# Altium schematic sheet MCU_unit.SchDoc
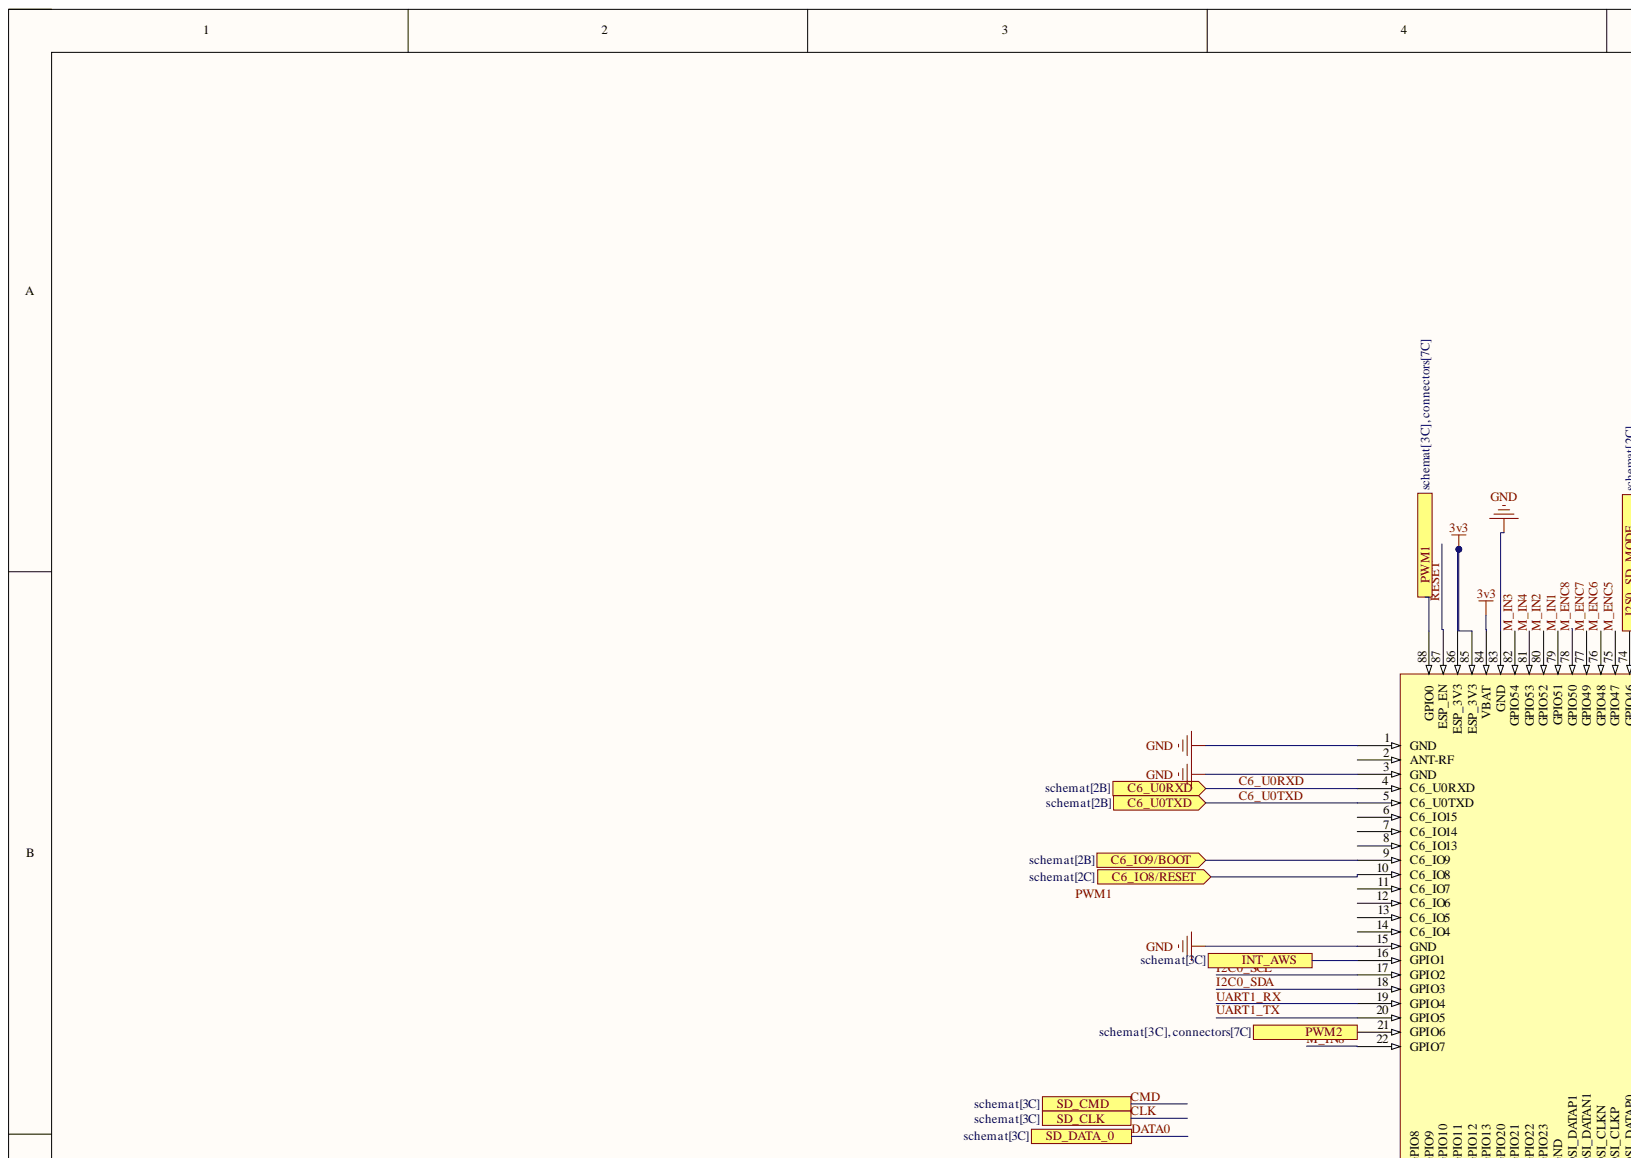
[diagram p0: MCU_unit.SchDoc, top left]
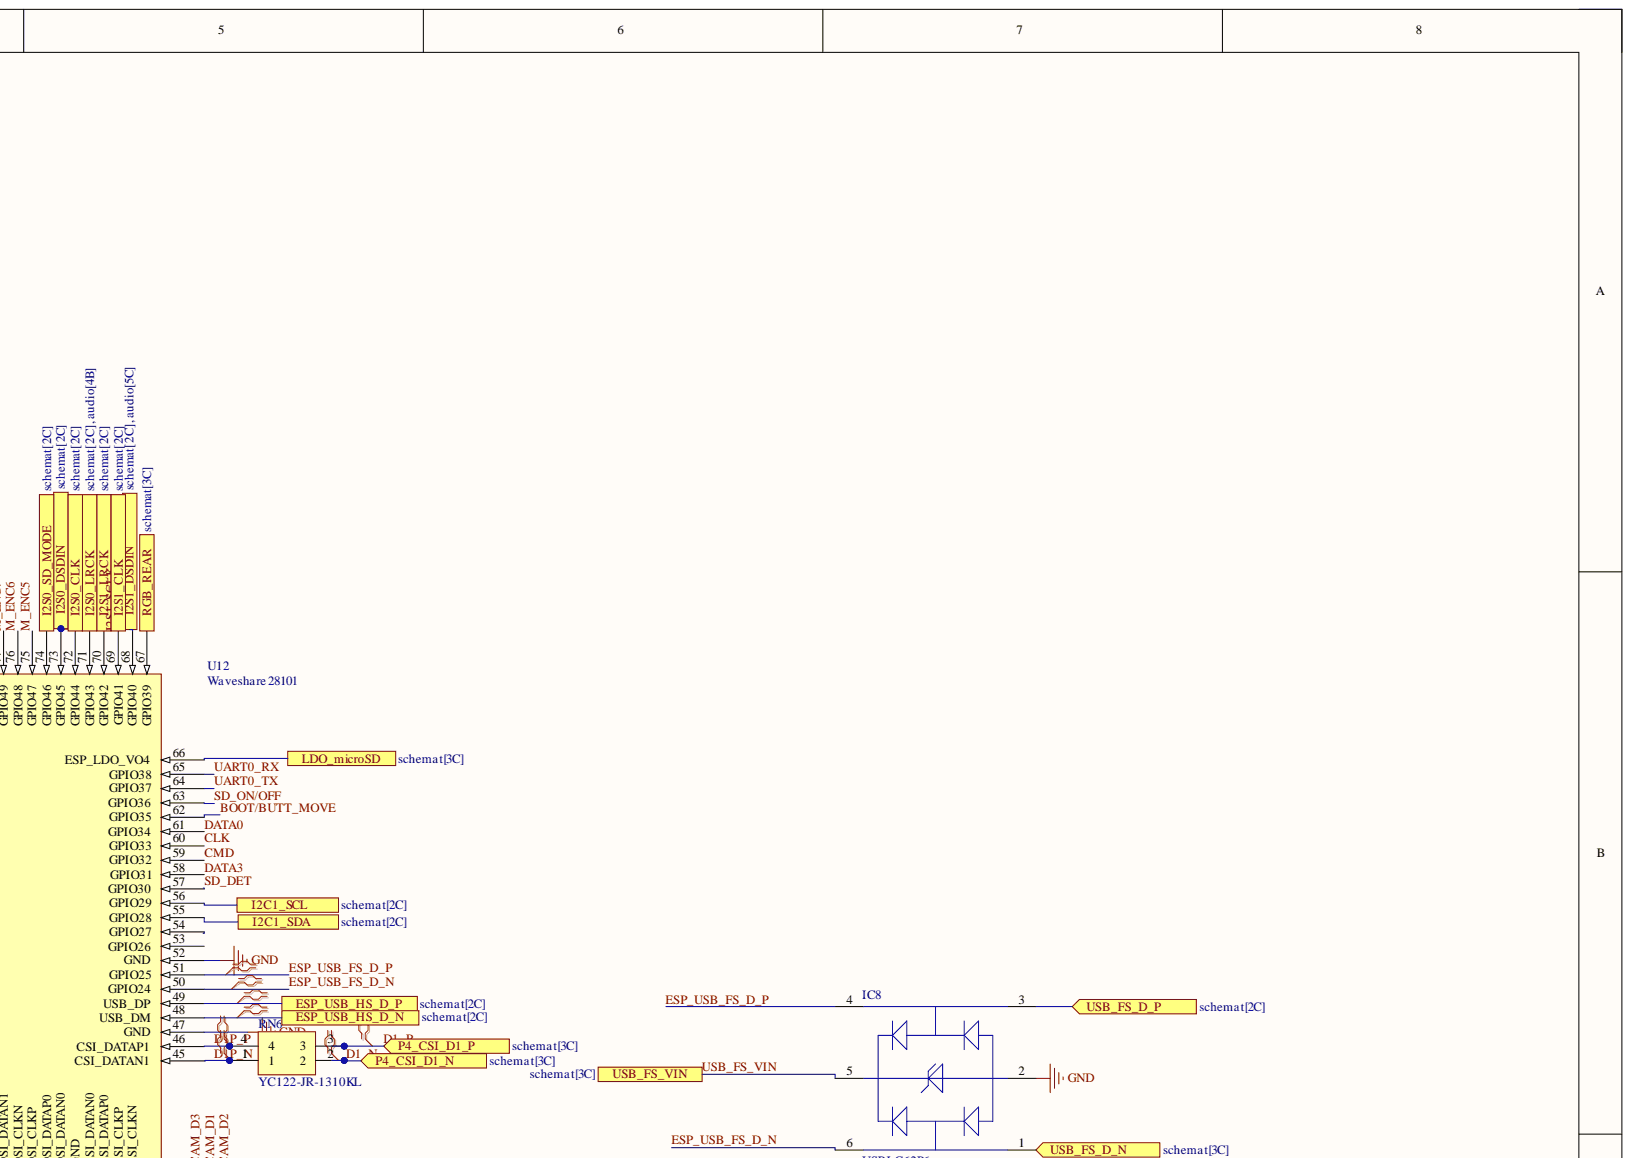
[diagram p1: MCU_unit.SchDoc, top right]
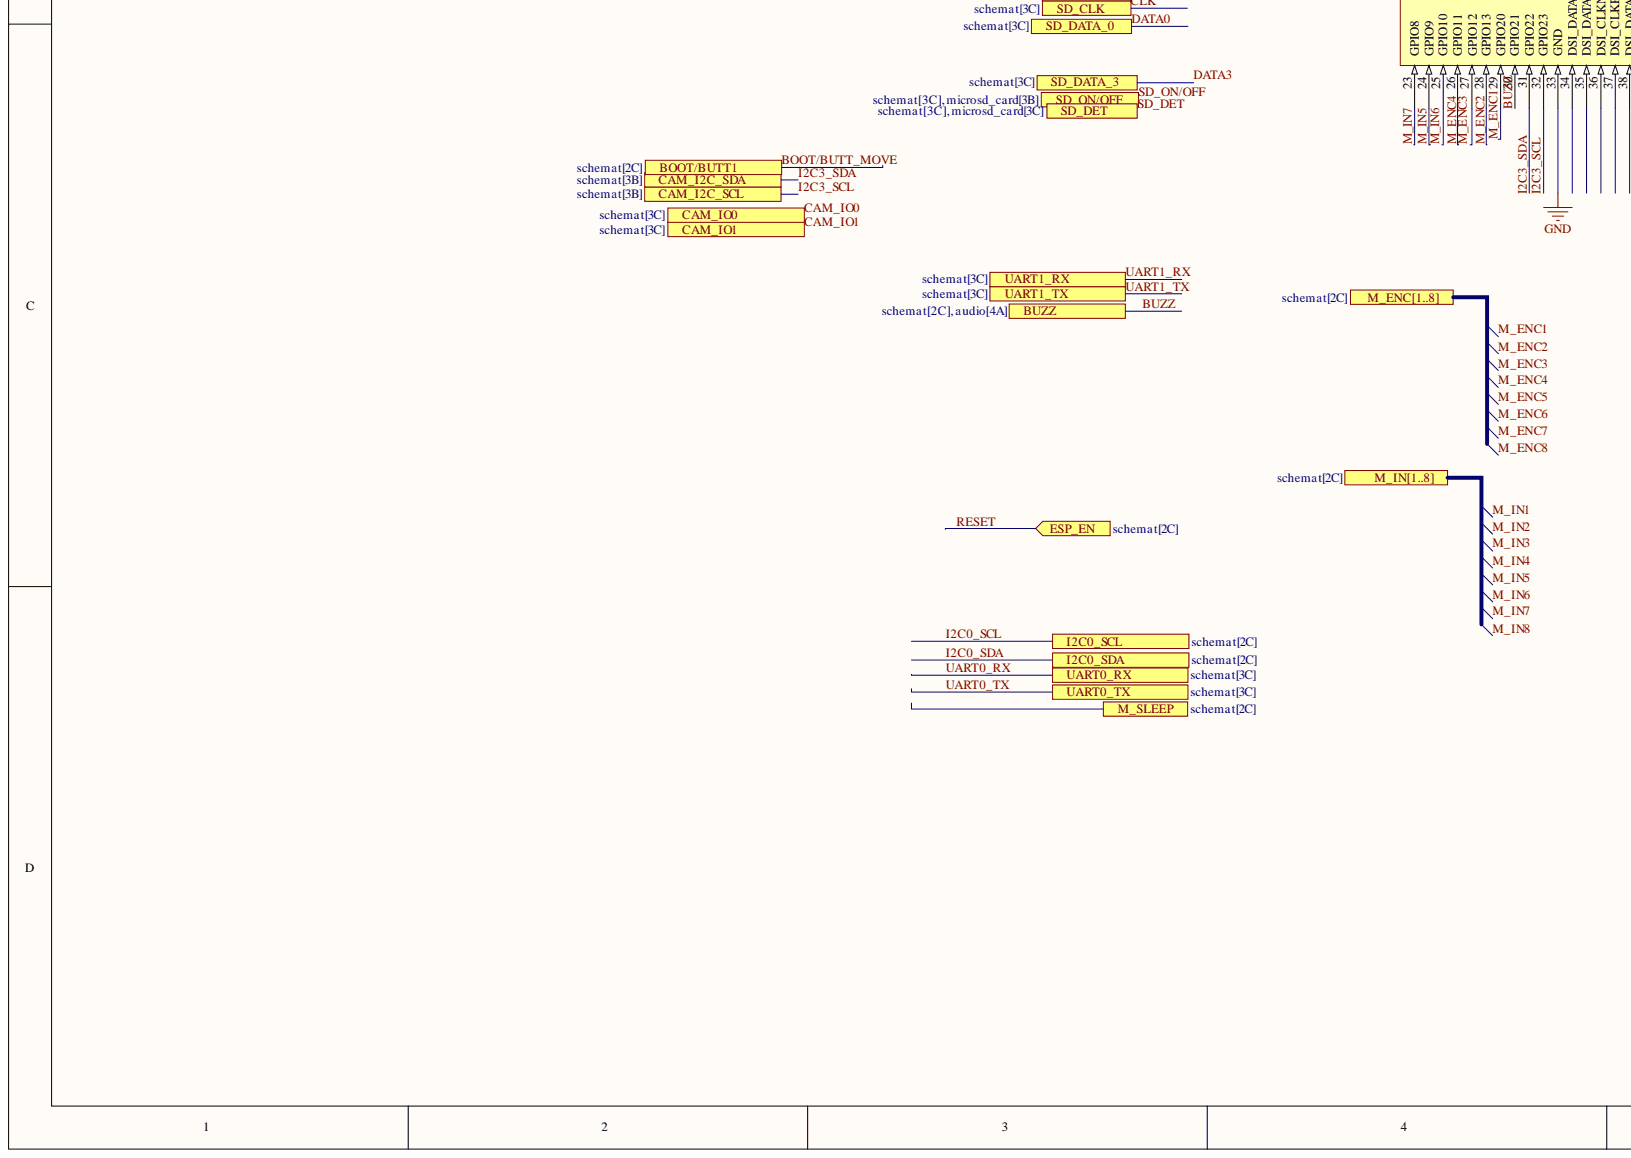
[diagram p2: MCU_unit.SchDoc, bottom left]
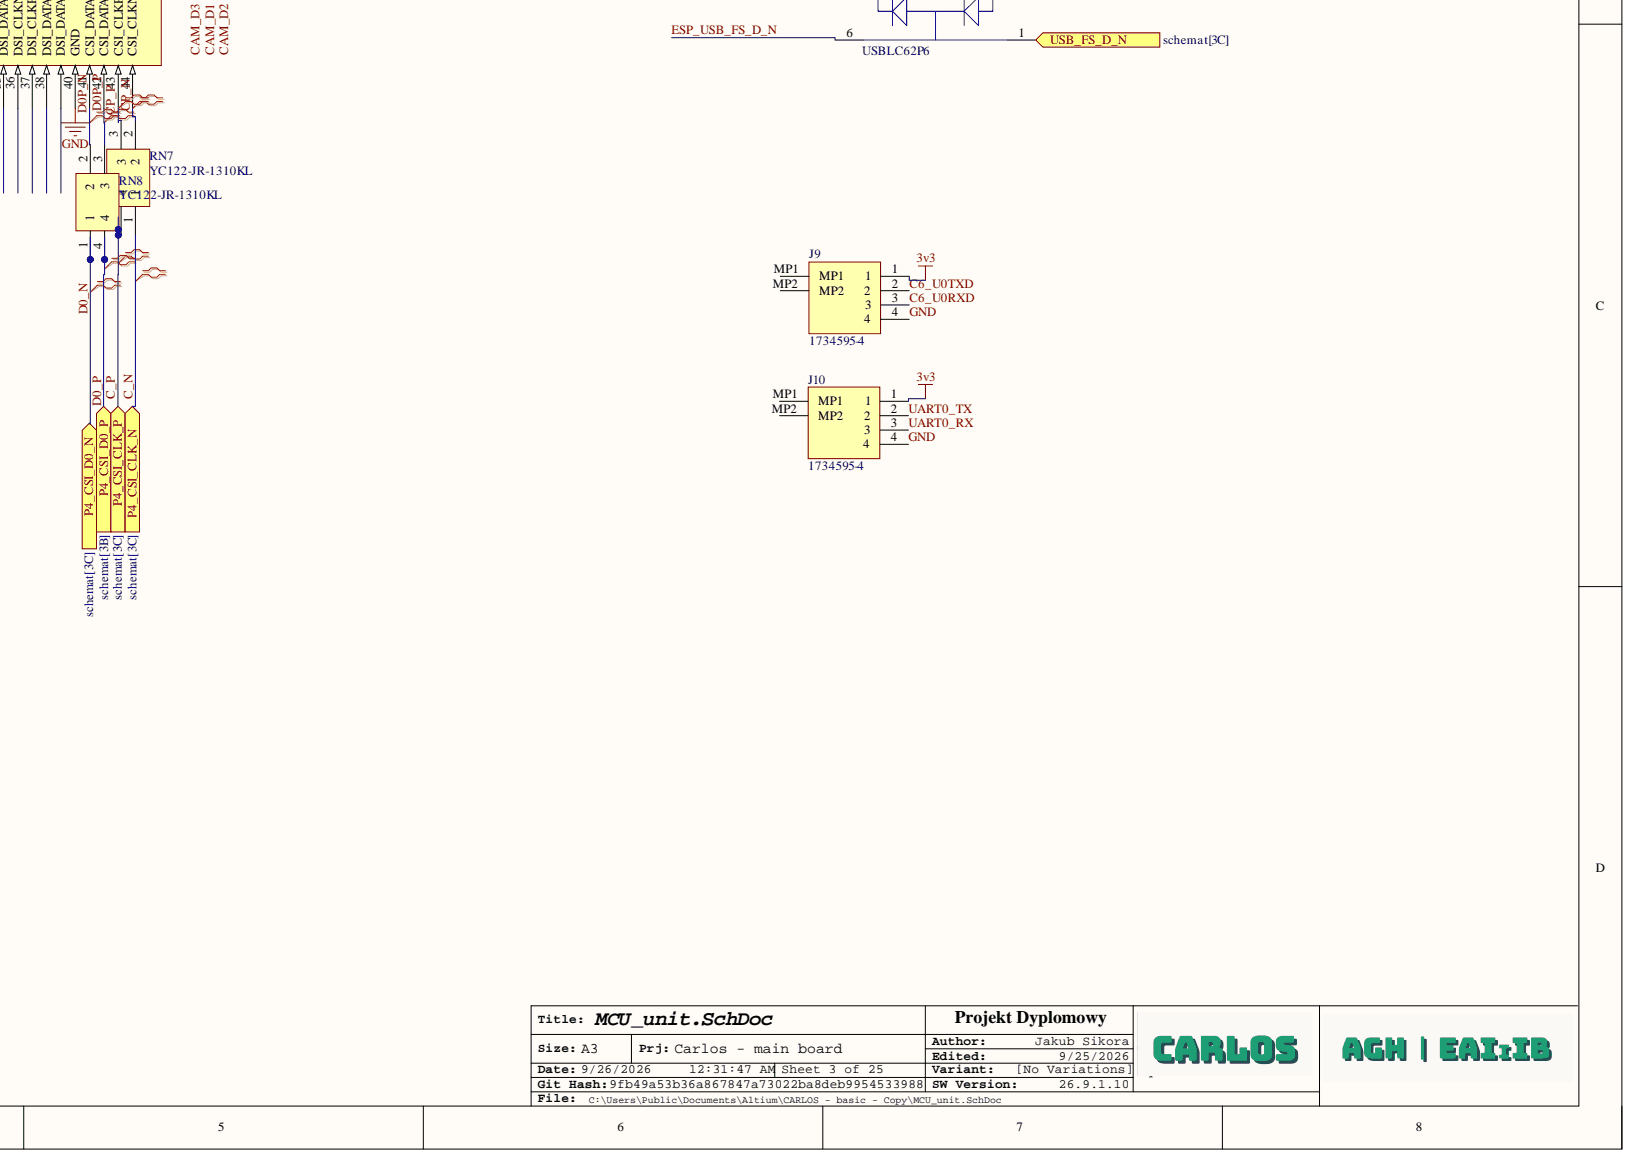
[diagram p3: MCU_unit.SchDoc, bottom right]

## Parts
IC8  USBLC62P6  [USBLC62P6]
J9  1734595-4  [17345954]
J10  1734595-4  [17345954]
RN6  YC122-JR-1310KL  [YC122JR0722KL]
RN7  YC122-JR-1310KL  [YC122JR0722KL]
RN8  YC122-JR-1310KL  [YC122JR0722KL]
U12  Waveshare 28101  [waveshare esp32p4]

## Ports
BOOT/BUTT1, BUZZ, C6_IO8/RESET, C6_IO9/BOOT, C6_U0RXD, C6_U0TXD, CAM_I2C_SCL, CAM_I2C_SDA, CAM_IO0, CAM_IO1, ESP_EN, ESP_USB_HS_D_N, ESP_USB_HS_D_P, I2C0_SCL, I2C0_SDA, I2C1_SCL, I2C1_SDA, I2S0_CLK, I2S0_DSDIN, I2S0_LRCK, I2S0_SD_MODE, I2S1_CLK, I2S1_DSDIN, I2S1_LRCK, INT_AWS, LDO_microSD, M_ENC1, M_ENC2, M_ENC3, M_ENC4, M_ENC5, M_ENC6, M_ENC7, M_ENC8, M_ENC[1..8], M_IN1, M_IN2, M_IN3, M_IN4, M_IN5, M_IN6, M_IN7, M_IN8, M_IN[1..8], M_SLEEP, P4_CSI_CLK_N, P4_CSI_CLK_P, P4_CSI_D0_N, P4_CSI_D0_P, P4_CSI_D1_N, P4_CSI_D1_P, PWM1, PWM2, RGB_REAR, SD_CLK, SD_CMD, SD_DATA_0, SD_DATA_3, SD_DET, SD_ON/OFF, UART0_RX, UART0_TX, UART1_RX, UART1_TX, USB_FS_D_N, USB_FS_D_P, USB_FS_VIN

## Nets
3v3: J9.1 J10.1 U12.84(VBAT) U12.85(ESP_3V3) U12.86(ESP_3V3)
BOOT/BUTT_MOVE: U12.62(GPIO35) ; port BOOT/BUTT1
BUZZ: U12.30(GPIO21) ; port BUZZ
C6_IO8/RESET: U12.10(C6_IO8) ; port C6_IO8/RESET
C6_IO9/BOOT: U12.9(C6_IO9) ; port C6_IO9/BOOT
C6_U0RXD: J9.3 U12.4(C6_U0RXD) ; port C6_U0RXD
C6_U0TXD: J9.2 U12.5(C6_U0TXD) ; port C6_U0TXD
C_N: RN7.1 ; port P4_CSI_CLK_N
C_P: RN7.4 ; port P4_CSI_CLK_P
CLK: U12.60(GPIO33) ; port SD_CLK
CMD: U12.59(GPIO32) ; port SD_CMD
CP_N: RN7.2 U12.44(CSI_CLKN)
CP_P: RN7.3 U12.43(CSI_CLKP)
D0_N: RN8.1 ; port P4_CSI_D0_N
D0_P: RN8.4 ; port P4_CSI_D0_P
D0P_N: RN8.2 U12.41(CSI_DATAN0)
D0P_P: RN8.3 U12.42(CSI_DATAP0)
D1_N: RN6.2 ; port P4_CSI_D1_N
D1_P: RN6.3 ; port P4_CSI_D1_P
D1P_N: RN6.1 U12.45(CSI_DATAN1)
D1P_P: RN6.4 U12.46(CSI_DATAP1)
DATA0: U12.61(GPIO34) ; port SD_DATA_0
DATA3: U12.58(GPIO31) ; port SD_DATA_3
ESP_USB_FS_D_N: IC8.6 U12.50(GPIO24)
ESP_USB_FS_D_P: IC8.4 U12.51(GPIO25)
ESP_USB_HS_D_N: U12.48(USB_DM) ; port ESP_USB_HS_D_N
ESP_USB_HS_D_P: U12.49(USB_DP) ; port ESP_USB_HS_D_P
GND: IC8.2 J9.4 J10.4 U12.1(GND) U12.3(GND) U12.15(GND) U12.33(GND) U12.40(GND) U12.47(GND) U12.52(GND) U12.83(GND)
I2C0_SCL: U12.17(GPIO2) ; port I2C0_SCL
I2C0_SDA: U12.18(GPIO3) ; port I2C0_SDA
I2C1_SCL: U12.56(GPIO29) ; port I2C1_SCL
I2C1_SDA: U12.55(GPIO28) ; port I2C1_SDA
I2C3_SCL: U12.32(GPIO23) ; port CAM_I2C_SCL
I2C3_SDA: U12.31(GPIO22) ; port CAM_I2C_SDA
I2S0_DSDIN: U12.73(GPIO45) ; port I2S0_DSDIN
I2S0_LRCK: U12.71(GPIO43) ; port I2S0_LRCK
I2S0_SCLK: U12.72(GPIO44) ; port I2S0_CLK
I2S0_SD_MODE: U12.74(GPIO46) ; port I2S0_SD_MODE
I2S1_DSDIN: U12.68(GPIO40) ; port I2S1_DSDIN
I2S1_LRCK: U12.70(GPIO42) ; port I2S1_LRCK
I2S1_SCLK: U12.69(GPIO41) ; port I2S1_CLK
INT_AWS: U12.16(GPIO1) ; port INT_AWS
LDO_microSD: U12.66(ESP_LDO_VO4) ; port LDO_microSD
M_ENC1: U12.29(GPIO20) ; port M_ENC[1..8]  [also labelled ]
M_ENC2: U12.28(GPIO13) ; port M_ENC[1..8]  [also labelled ]
M_ENC3: U12.27(GPIO12) ; port M_ENC[1..8]  [also labelled ]
M_ENC4: U12.26(GPIO11) ; port M_ENC[1..8]  [also labelled ]
M_ENC5: U12.75(GPIO47) ; port M_ENC[1..8]  [also labelled ]
M_ENC6: U12.76(GPIO48) ; port M_ENC[1..8]  [also labelled ]
M_ENC7: U12.77(GPIO49) ; port M_ENC[1..8]  [also labelled ]
M_ENC8: U12.78(GPIO50) ; port M_ENC[1..8]  [also labelled ]
M_IN1: U12.79(GPIO51) ; port M_IN[1..8]  [also labelled ]
M_IN2: U12.80(GPIO52) ; port M_IN[1..8]  [also labelled ]
M_IN3: U12.82(GPIO54) ; port M_IN[1..8]  [also labelled ]
M_IN4: U12.81(GPIO53) ; port M_IN[1..8]  [also labelled ]
M_IN5: U12.24(GPIO9) ; port M_IN[1..8]  [also labelled ]
M_IN6: U12.25(GPIO10) ; port M_IN[1..8]  [also labelled ]
M_IN7: U12.23(GPIO8) ; port M_IN[1..8]  [also labelled ]
M_IN8: U12.22(GPIO7) ; port M_IN[1..8]  [also labelled ]
PWM1: U12.88(GPIO0) ; port PWM1
PWM2: U12.21(GPIO6) ; port PWM2
RESET: U12.87(ESP_EN) ; port ESP_EN
RGB_REAR: U12.67(GPIO39) ; port RGB_REAR
SD_DET: U12.57(GPIO30) ; port SD_DET
SD_ON/OFF: U12.63(GPIO36) ; port SD_ON/OFF
UART0_RX: J10.3 U12.65(GPIO38) ; port UART0_RX
UART0_TX: J10.2 U12.64(GPIO37) ; port UART0_TX
UART1_RX: U12.19(GPIO4) ; port UART1_RX
UART1_TX: U12.20(GPIO5) ; port UART1_TX
USB_FS_D_N: IC8.1 ; port USB_FS_D_N
USB_FS_D_P: IC8.3 ; port USB_FS_D_P
USB_FS_VIN: IC8.5 ; port USB_FS_VIN
NetJ9_MP1: J9.MP1
NetJ9_MP2: J9.MP2
NetU12_6: U12.6(C6_IO15)
NetU12_7: U12.7(C6_IO14)
CAM_D1:
CAM_D2:
CAM_D3:
CAM_IO0: ; port CAM_IO0
CAM_IO1: ; port CAM_IO1
N00003: ; port M_SLEEP
PWM1:

Not connected: J10 MP1 MP2; U12 2(ANT-RF) 8(C6_IO13) 11(C6_IO7) 12(C6_IO6) 13(C6_IO5) 14(C6_IO4) 34(DSI_DATAP1) 35(DSI_DATAN1) 36(DSI_CLKN) 37(DSI_CLKP) 38(DSI_DATAP0) 39(DSI_DATAN0) 53(GPIO26) 54(GPIO27)

## Library data of the parts
IC8 = USBLC62P6: Integrated Circuit; Manufacturer_Name: STMicroelectronics; Component Kind: Standard; Datasheet Link: https://datasheet.lcsc.com/szlcsc/STMicroelectronics-USBLC6-2P6_C15999.pdf; Height: 0.6mm; Manufacturer_Part_Number: USBLC62P6
J9 J10 = 1734595-4: Connector; Manufacturer_Name: TE Connectivity; Component Kind: Standard; Datasheet Link: https://www.te.com/commerce/DocumentDelivery/DDEController?Action=showdoc&DocId=Customer+Drawing%7F1734595%7FA4%7Fpdf%7FEnglish%7FENG_CD_1734595_A4.pdf%7F1734595-4; Height: 5.05mm; Manufacturer_Part_Number: 1734595-4; Mouser Part Number: 571-1734595-4; Mouser Price/Stock: https://www.mouser.co.uk/ProductDetail/TE-Connectivity-Alcoswitch/1734595-4?qs=fvVej%2FMj3jdYJBbjmfDIiA%3D%3D
RN6-RN8 = YC122-JR-1310KL: Resistor Network; Manufacturer_Name: Yageo Group; Component Kind: Standard; Datasheet Link: http://www.mouser.com/datasheet/2/447/PYu-YC_TC_group_51_RoHS_L_7-1313905.pdf; Height: 0.4mm; Library Name: SamacSys.SchLib; Manufacturer_Part_Number: YC122-JR-1310KL; Mouser Part Number: 603-YC122-JR-1310KL; Mouser Price/Stock: https://www.mouser.co.uk/ProductDetail/YAGEO/YC122-JR-1310KL?qs=CNuWj9FTWYBv0Ob3K%252BulWA%3D%3D
U12 = Waveshare 28101: Component Kind: Standard; Library Name: SamacSys.SchLib
